# Altium schematic sheet Glitch.SchDoc
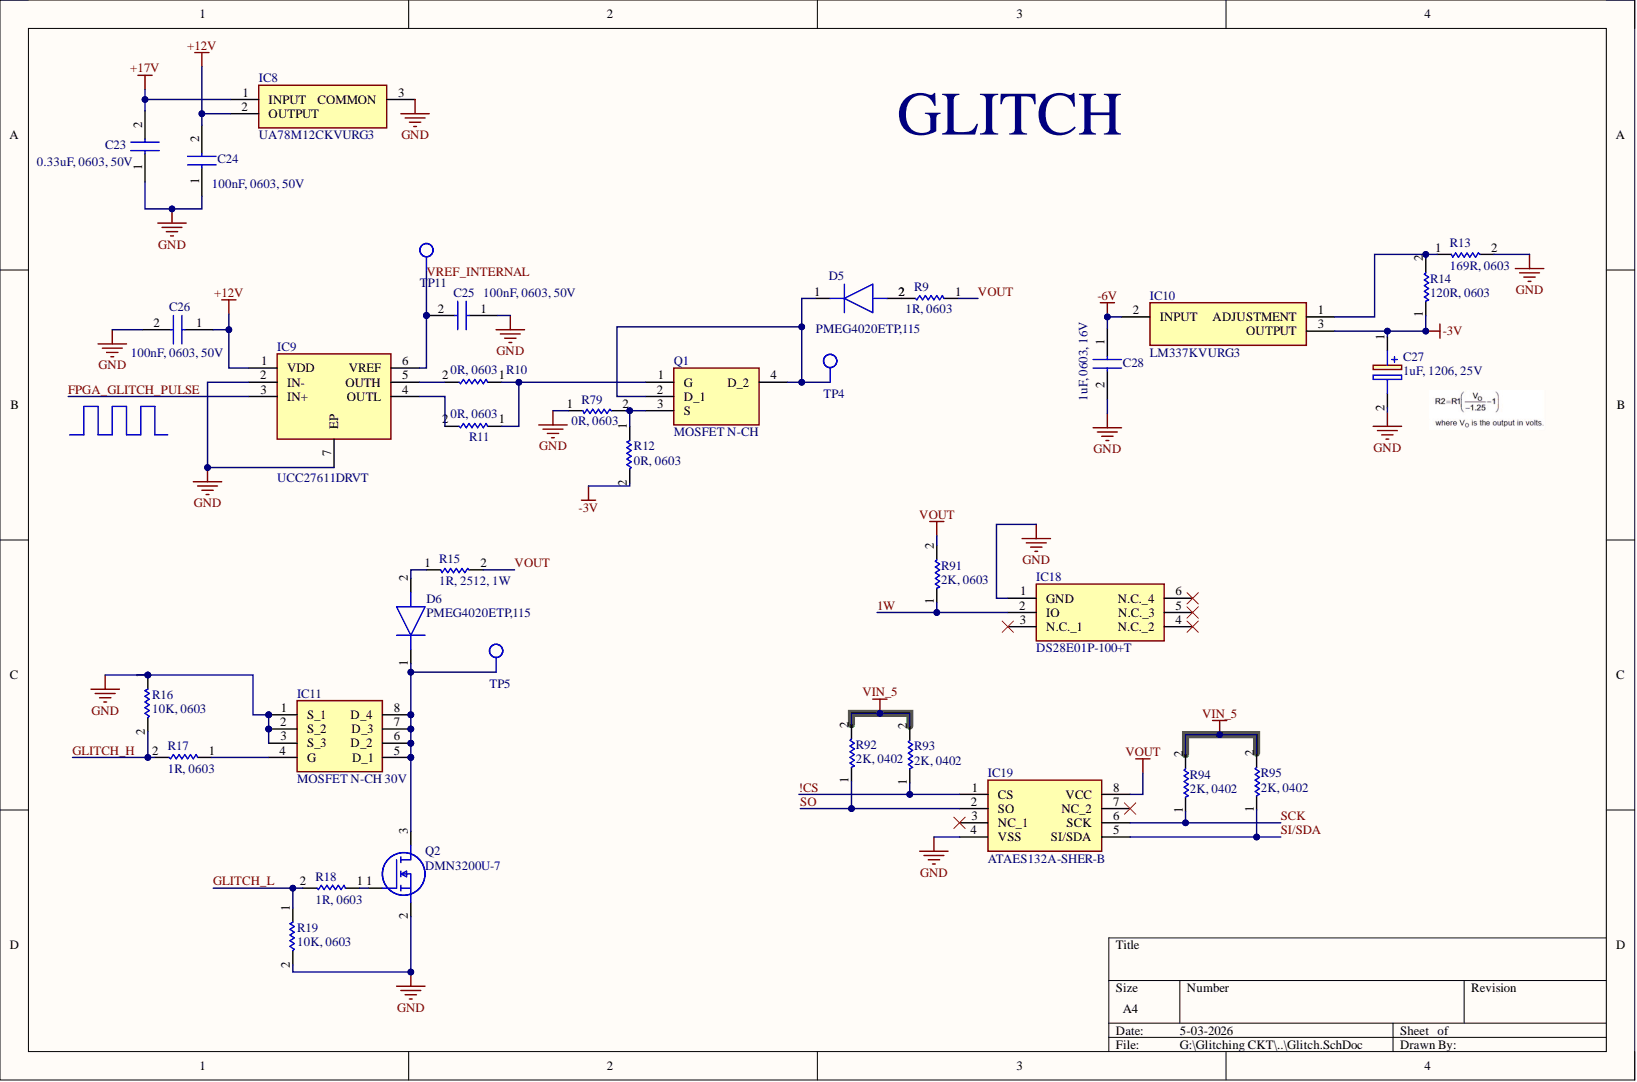
[diagram p0: Glitch.SchDoc]

## Parts
C23  0.33uF, 0603, 50V  [CAP0603]
C24  100nF, 0603, 50V  [CAP0603]
C25  100nF, 0603, 50V  [CAP0603]
C26  100nF, 0603, 50V  [CAP0603]
C27  1uF, 1206, 25V  [CAPTANT_1206]
C28  1uF, 0603, 16V  [CAP0603]
D5  PMEG4020ETP,115  [SODFL4725X110N]
D6  PMEG4020ETP,115  [SODFL4725X110N]
IC8  UA78M12CKVURG3  [KVU-(R-PSFM-G3)]
IC9  UCC27611DRVT  [SON65P200X200X80-7N]
IC10  LM337KVURG3  [LM337KVURG3]
IC11  MOSFET N-CH 30V  [SOIC127P600X175-8N]
IC18  DS28E01P-100+T  [DS28E01P100T]
IC19  ATAES132A-SHER-B  [SOIC127P600X175-8N]
Q1  MOSFET N-CH  [SOT230P700X180-4N]
Q2  DMN3200U-7  [SOT96P240X110-3N]
R9  1R, 0603  [RES0603]
R10  0R, 0603  [RES0603]
R11  0R, 0603  [RES0603]
R12  0R, 0603  [RES0603]
R13  169R, 0603  [RES0603]
R14  120R, 0603  [RES0603]
R15  1R, 2512, 1W  [RES0603]
R16  10K, 0603  [RES0603]
R17  1R, 0603  [RES0603]
R18  1R, 0603  [RES0603]
R19  10K, 0603  [RES0603]
R79  0R, 0603  [RES0603]
R91  2K, 0603  [RES0603]
R92  2K, 0402  [RES0402]
R93  2K, 0402  [RES0402]
R94  2K, 0402  [RES0402]
R95  2K, 0402  [RES0402]
TP4  Test Point  [TP_1mm]  (value not drawn)
TP5  Test Point  [TP_1mm]  (value not drawn)
TP11  Test Point  [TP_1mm]  (value not drawn)

## Nets
+12V: C24.2 C26.1 IC8.2 IC9.1(VDD)
+17V: C23.2 IC8.1
-3V: C27.1 IC10.3 R12.2 R14.1
-6V: C28.1 IC10.2
GND: C23.1 C24.1 C25.1 C26.2 C27.2 C28.2 IC8.3 IC9.2(IN-) IC9.7(EP) IC11.1(S_1) IC11.2(S_2) IC11.3(S_3) IC18.1(GND) IC19.4(VSS) Q2.2 R13.2 R16.1 R19.2 R79.1
VIN_5: R92.2 R93.2 R94.2 R95.2
1W: IC18.2(IO) R91.1
!CS: IC19.1(CS) R93.1
FPGA_GLITCH_PULSE: IC9.3(IN+)
GLITCH_H: R16.2 R17.2
GLITCH_L: R18.2 R19.1
SCK: IC19.6(SCK) R94.1
SI/SDA: IC19.5(SI/SDA) R95.1
SO: IC19.2(SO) R92.1
VOUT: IC19.8(VCC) R9.1 R15.2 R91.2
VREF_INTERNAL: C25.2 IC9.6(VREF) TP11.1
NetD5_1: D5.1 Q1.2(D_1) Q1.4(D_2) TP4.1
NetD5_2: D5.2 R9.2
NetD6_1: D6.1 IC11.5(D_1) IC11.6(D_2) IC11.7(D_3) IC11.8(D_4) Q2.3 TP5.1
NetD6_2: D6.2 R15.1
NetIC9_4: IC9.4(OUTL) R11.2
NetIC9_5: IC9.5(OUTH) R10.2
NetIC10_1: IC10.1 R13.1 R14.2
NetIC11_4: IC11.4(G) R17.1
NetQ1_1: Q1.1(G) R10.1 R11.1
NetQ1_3: Q1.3(S) R12.1 R79.2
NetQ2_1: Q2.1 R18.1

Not connected: IC18 3(N.C._1) 4(N.C._2) 5(N.C._3) 6(N.C._4); IC19 3(NC_1) 7(NC_2)

## Library data of the parts
C23 = Capacitor: CAP CER 0.33UF 50V X7R 0603; Manufacturer_Name: TDK Corporation; Manufacturer_Part_Number: CGA3E3X7R1H334K080AB; Component Kind: Standard; Library Name: Sanket.SchLib
C24-C26 = Capacitor: CAP CER 0.1UF 50V X7R 0603; Manufacturer_Name: KEMET; Manufacturer_Part_Number: C0603C104K5RACTU; Component Kind: Standard; Library Name: Sanket.SchLib
C27 = Tantalum Capacitor: CAP TANT 1UF 10% 25V 1206; Manufacturer_Name: KYOCERA AVX; Manufacturer_Part_Number: TAJA105K025RNJ; Component Kind: Standard; Library Name: Sanket.SchLib
C28 = Capacitor: CAP CER 1UF 16V X7R 0603; Manufacturer_Name: Samsung Electro-Mechanics; Manufacturer_Part_Number: CL10B105KO8NNNC; Component Kind: Standard; Library Name: Sanket.SchLib
D5 D6 = PMEG4020ETP,115: Diode; Manufacturer_Name: Nexperia; Component Kind: Standard; Manufacturer_Part_Number: PMEG4020ETP,115; Library Name: Sanket.SchLib
IC8 = UA78M12CKVURG3: Integrated Circuit; Manufacturer_Name: Texas Instruments; Component Kind: Standard; Manufacturer_Part_Number: UA78M12CKVURG3
IC9 = UCC27611DRVT: Integrated Circuit; Manufacturer_Name: Texas Instruments; Component Kind: Standard; Manufacturer_Part_Number: UCC27611DRVT
IC10 = LM337KVURG3: Integrated Circuit; Manufacturer_Name: Texas Instruments; Component Kind: Standard; Manufacturer_Part_Number: LM337KVURG3
IC11 = IRF7807ZTRPBF: MOSFET N-CH 30V 11A 8SOIC; Manufacturer_Name: Infineon; Manufacturer_Part_Number: FDS6690A; Component Kind: Standard
IC18 = DS28E01P-100+T: IC EEPROM 1KBIT 1-WIRE 6TSOC; Manufacturer_Name: Analog Devices; Component Kind: Standard; Manufacturer_Part_Number: DS28E01P-100+T; Library Name: Sanket.SchLib
IC19 = ATAES132A-SHER-B: Integrated Circuit; Manufacturer_Name: Microchip; Arrow Part Number: ATAES132A-SHER-B; Arrow Price/Stock: https://www.arrow.com/en/products/ataes132a-sher-b/microchip-technology?region=nac; Component Kind: Standard; Datasheet Link: https://componentsearchengine.com/Datasheets/2/ATAES132A-SHER-B.pdf; Height: 1.75mm; Manufacturer_Part_Number: ATAES132A-SHER-B; Mouser Part Number: 556-ATAES132A-SHER-B; Mouser Price/Stock: https://www.mouser.co.uk/ProductDetail/Microchip-Technology-Atmel/ATAES132A-SHER-B?qs=9KdFJXLqUo%2FaVYGdCdKzLQ%3D%3D
Q1 = BSP89,115: MOSFET N-CH 30V 5A SOT223-4; Manufacturer_Name: onsemi; Manufacturer_Part_Number: FDT457N; Component Kind: Standard
Q2 = DMN3200U-7: MOSFET (N-Channel); Manufacturer_Name: Diodes Incorporated; Component Kind: Standard; Manufacturer_Part_Number: DMN3200U-7
R9 R17 R18 = Resistor 0603: RES 1 OHM 1% 1/10W 0603; Manufacturer_Name: Panasonic Electronic Components; Manufacturer_Part_Number: ERJ-3RQF1R0V; Component Kind: Standard; Library Name: Sanket.SchLib
R10-R12 R79 = Resistor 0603: RES 0 OHM JUMPER 1/10W 0603; Manufacturer_Name: Stackpole Electronics Inc; Manufacturer_Part_Number: RMCF0603ZT0R00; Component Kind: Standard; Library Name: Sanket.SchLib
R13 = Resistor 0603: RES 169 OHM 1% 1/10W 0603; Manufacturer_Name: YAGEO; Manufacturer_Part_Number: RC0603FR-07169RL; Component Kind: Standard; Library Name: Sanket.SchLib
R14 = Resistor 0603: RES SMD 120 OHM 1% 1/10W 0603; Manufacturer_Name: Panasonic Electronic Components; Manufacturer_Part_Number: ERJ-3EKF1200V; Component Kind: Standard; Library Name: Sanket.SchLib
R15 = Resistor: RES 1 OHM 1% 1W 2512; Manufacturer_Name: Stackpole Electronics Inc; Manufacturer_Part_Number: RMCF2512FT1R00; Component Kind: Standard; Library Name: Sanket.SchLib
R16 R19 = Resistor 0603: RES 10K OHM 1% 1/10W 0603; Manufacturer_Name: YAGEO; Manufacturer_Part_Number: RC0603FR-0710KL; Component Kind: Standard; Library Name: Sanket.SchLib
R91 = Resistor: RES 2K OHM 1% 1/10W 0603; Manufacturer_Name: YAGEO; Manufacturer_Part_Number: RC0603FR-072KL; Component Kind: Standard; Library Name: Sanket.SchLib
R92-R95 = Resistor: RES 2K OHM 1% 1/16W 0402; Manufacturer_Name: YAGEO; Manufacturer_Part_Number: RC0402FR-072KL; Component Kind: Standard; Library Name: Sanket.SchLib
TP4 TP5 TP11 = Test Point: Component Kind: Standard; Library Name: Sanket.SchLib
